# Altium schematic sheet main.SchDoc(main)
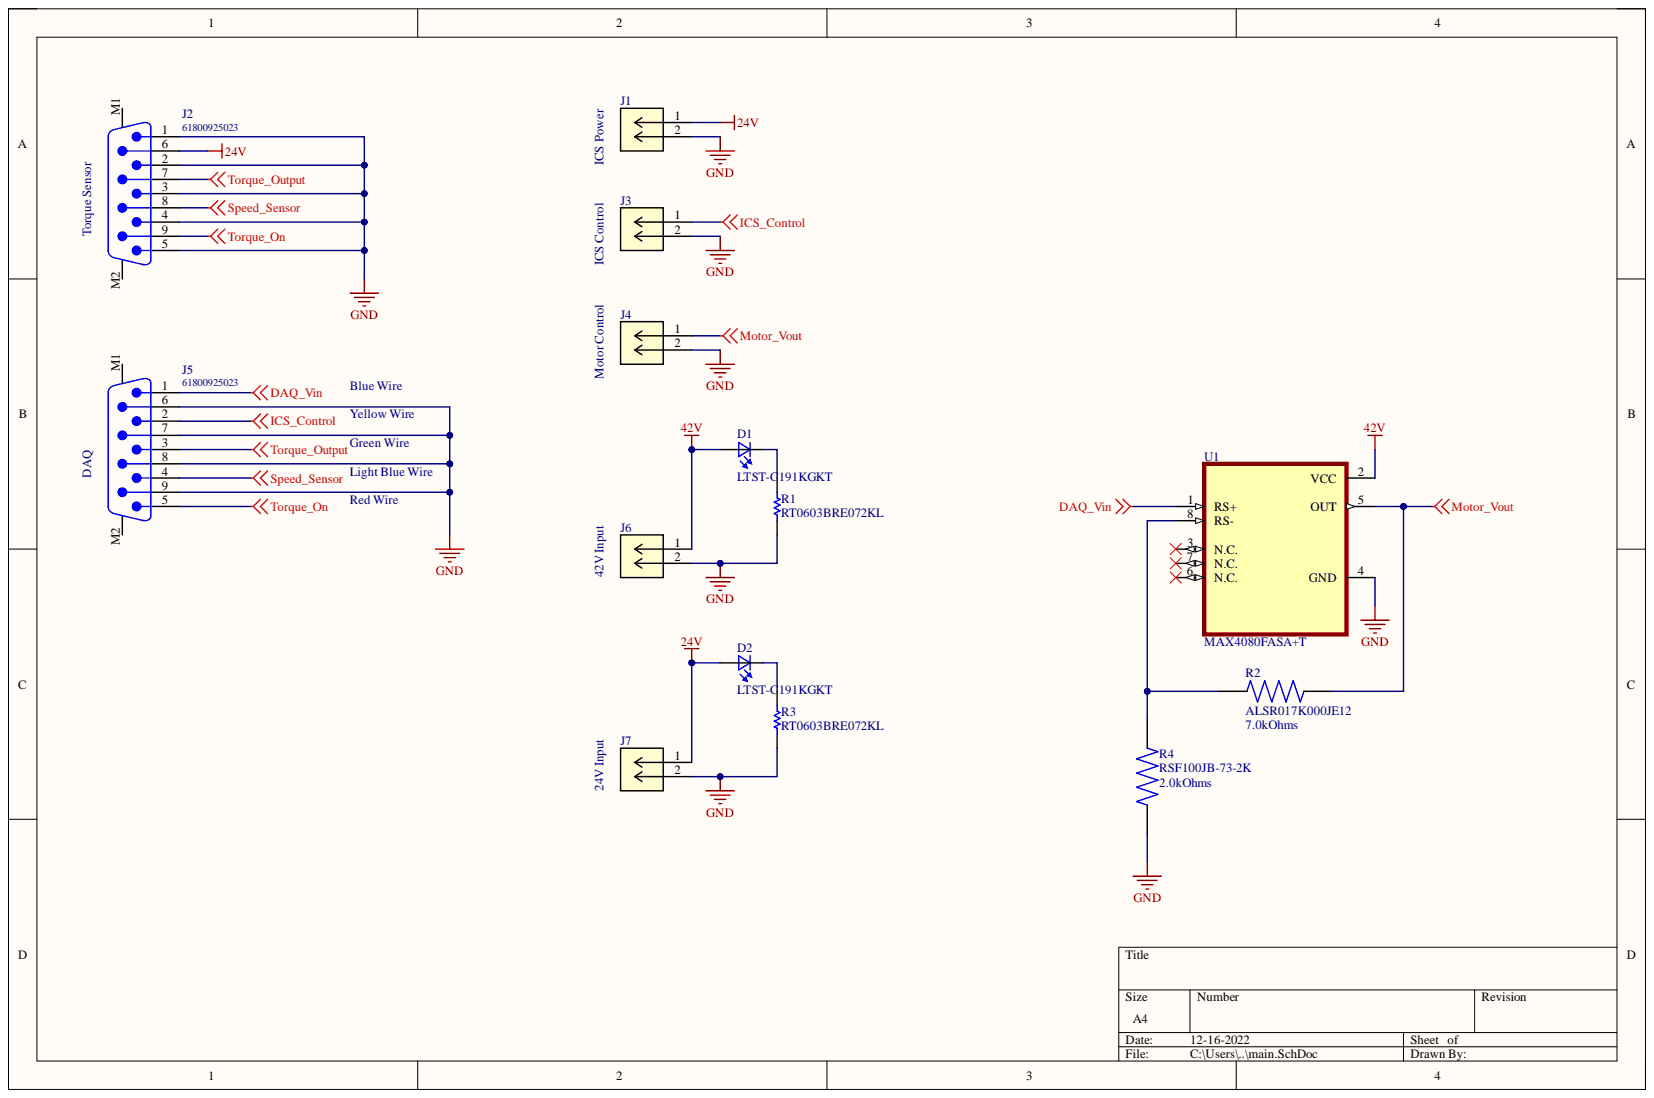
[diagram p0: main.SchDoc]

## Parts
D1  LTST-C191KGKT  [0603]
D2  LTST-C191KGKT  [0603]
J1  https://www.digikey.ca/en/products/detail/molex/0731375003/1465136  [CONN_73137-5003_MOL]  (value not drawn)
J2  61800925023  [61800925023]
J3  https://www.digikey.ca/en/products/detail/molex/0731375003/1465136  [CONN_73137-5003_MOL]  (value not drawn)
J4  https://www.digikey.ca/en/products/detail/molex/0731375003/1465136  [CONN_73137-5003_MOL]  (value not drawn)
J5  61800925023  [61800925023]
J6  https://www.digikey.ca/en/products/detail/molex/0731375003/1465136  [CONN_73137-5003_MOL]  (value not drawn)
J7  https://www.digikey.ca/en/products/detail/molex/0731375003/1465136  [CONN_73137-5003_MOL]  (value not drawn)
R1  RT0603BRE072KL  [RESC1608X55X25LL10T15]
R2  ALSR017K000JE12  value 7.0kOhms  [Thru Hole Resistor]
R3  RT0603BRE072KL  [RESC1608X55X25LL10T15]
R4  RSF100JB-73-2K  value 2.0kOhms  [Thru Hole Resistor]
U1  MAX4080FASA+T  [8-SOIC (0.154", 3.90mm Width)]

## Nets
24V: D2.2 J1.1 J2.6 J7.1
42V: D1.2 J6.1 U1.2(VCC)
DAQ_Vin: J5.1 U1.1(RS+)
GND: J1.2 J2.1 J2.2 J2.3 J2.4 J2.5 J3.2 J4.2 J5.6 J5.7 J5.8 J5.9 J6.2 J7.2 R1.1 R3.1 R4.1 U1.4(GND)
ICS_Control: J3.1 J5.2
Motor_Vout: J4.1 R2.2 U1.5(OUT)
Speed_Sensor: J2.8 J5.4
Torque_On: J2.9 J5.5
Torque_Output: J2.7 J5.3
NetD1_1: D1.1 R1.2
NetD2_1: D2.1 R3.2
NetR2_1: R2.1 R4.2 U1.8(RS-)

Not connected: J2 M1 M2; J5 M1 M2; U1 3(N.C.) 6(N.C.) 7(N.C.)

## Library data of the parts
D1 D2 = LTST-C191KGKT: Green 571nm LED Indication - Discrete 2V 0603 _1608 Metric_; MF: Lite-On Inc.; Availability: Unavailable; Component Kind: Standard; Library Name: Control_Board.SchLib; MP: LTST-C191KGKT; Package: 0603 Lite-On; Price: None; SnapEDA_Link: https://www.snapeda.com/parts/LTST-C191KGKT/Lite-On%20Inc./view-part/48565/?ref=snap
J1 J3 J4 J6 J7 = 731375003: Mfr_Name: Molex Connector Corporation; Component Kind: Standard; Description: https://www.digikey.ca/en/products/detail/molex/0731375003/1465136; Library Name: Control_Board.SchLib; Manufacturer_Part_Number: 731375003; RefDes: RefDes; Type: DEV; Copyright: Copyright (C) 2022 Ultra Librarian. All rights reserved.
J2 J5 = CMP-1502-00276-1: Male PCB Connector WR-DSUB, Vertical, 9 pins; Manufacturer: Wurth Elektronik; Contact Resistance: 30mOhm; Pin Number: 9; Rated Current: 3A; Working Voltage: 125VAC; Component Kind: Standard; Datasheet URL: https://katalog.we-online.de/em/datasheet/6180xx25023.pdf; Gender: Male; Library Name: Altium Content Vault; Manufacturer URL: http://www.we-online.de; Mounting Technology: THT; Operating Temperature: -40to85degC; Orientation: Vertical; Package Reference: 61800925023; Packaging: Tray; Part Number: 61800925023; Publisher: WurthElektronik
R1 R3 = CMP-2000-04536-1: Manufacturer: Yageo; Component Kind: Standard; Library Name: Altium Content Vault; Part Number: RT0603BRE072KL
R2 = ALSR017K000JE12: 7 kOhms ±5% 1W Through Hole Resistor Axial Moisture Resistant Wirewound; Manufacturer: Vishay Dale; Seller Part Number: ALSR1J-7.0K-ND; Component Kind: Standard; Library Name: Control_Board.SchLib; Manufacturer Product Number: ALSR017K000JE12
R4 = RSF100JB-73-2K: 2 kOhms ±5% 1W Through Hole Resistor Axial Flame Proof, Safety Metal Oxide Film; Manufacturer: YAGEO; Seller Part Number: 2.0KW-1-ND; Component Kind: Standard; Library Name: Control_Board.SchLib; Manufacturer Product Number: RSF100JB-73-2K
U1 = MAX4080FASA+T: Current Sense Amplifier 1 Circuit - 8-SOIC; MF: Maxim Integrated; Availability: In Stock; Check_prices: https://www.snapeda.com/parts/MAX4080FASA+T/Maxim+Integrated/view-part/?ref=eda; Component Kind: Standard; Library Name: Control_Board.SchLib; MP: MAX4080FASA+T; Package: SOIC-8 Maxim; Price: None; Purchase-URL: https://pricing.snapeda.com/search/part/MAX4080FASA+T/?ref=eda; SnapEDA_Link: https://www.snapeda.com/parts/MAX4080FASA+T/Maxim+Integrated/view-part/?ref=snap
